# Altium schematic sheet CHC5_Regs.SchDoc
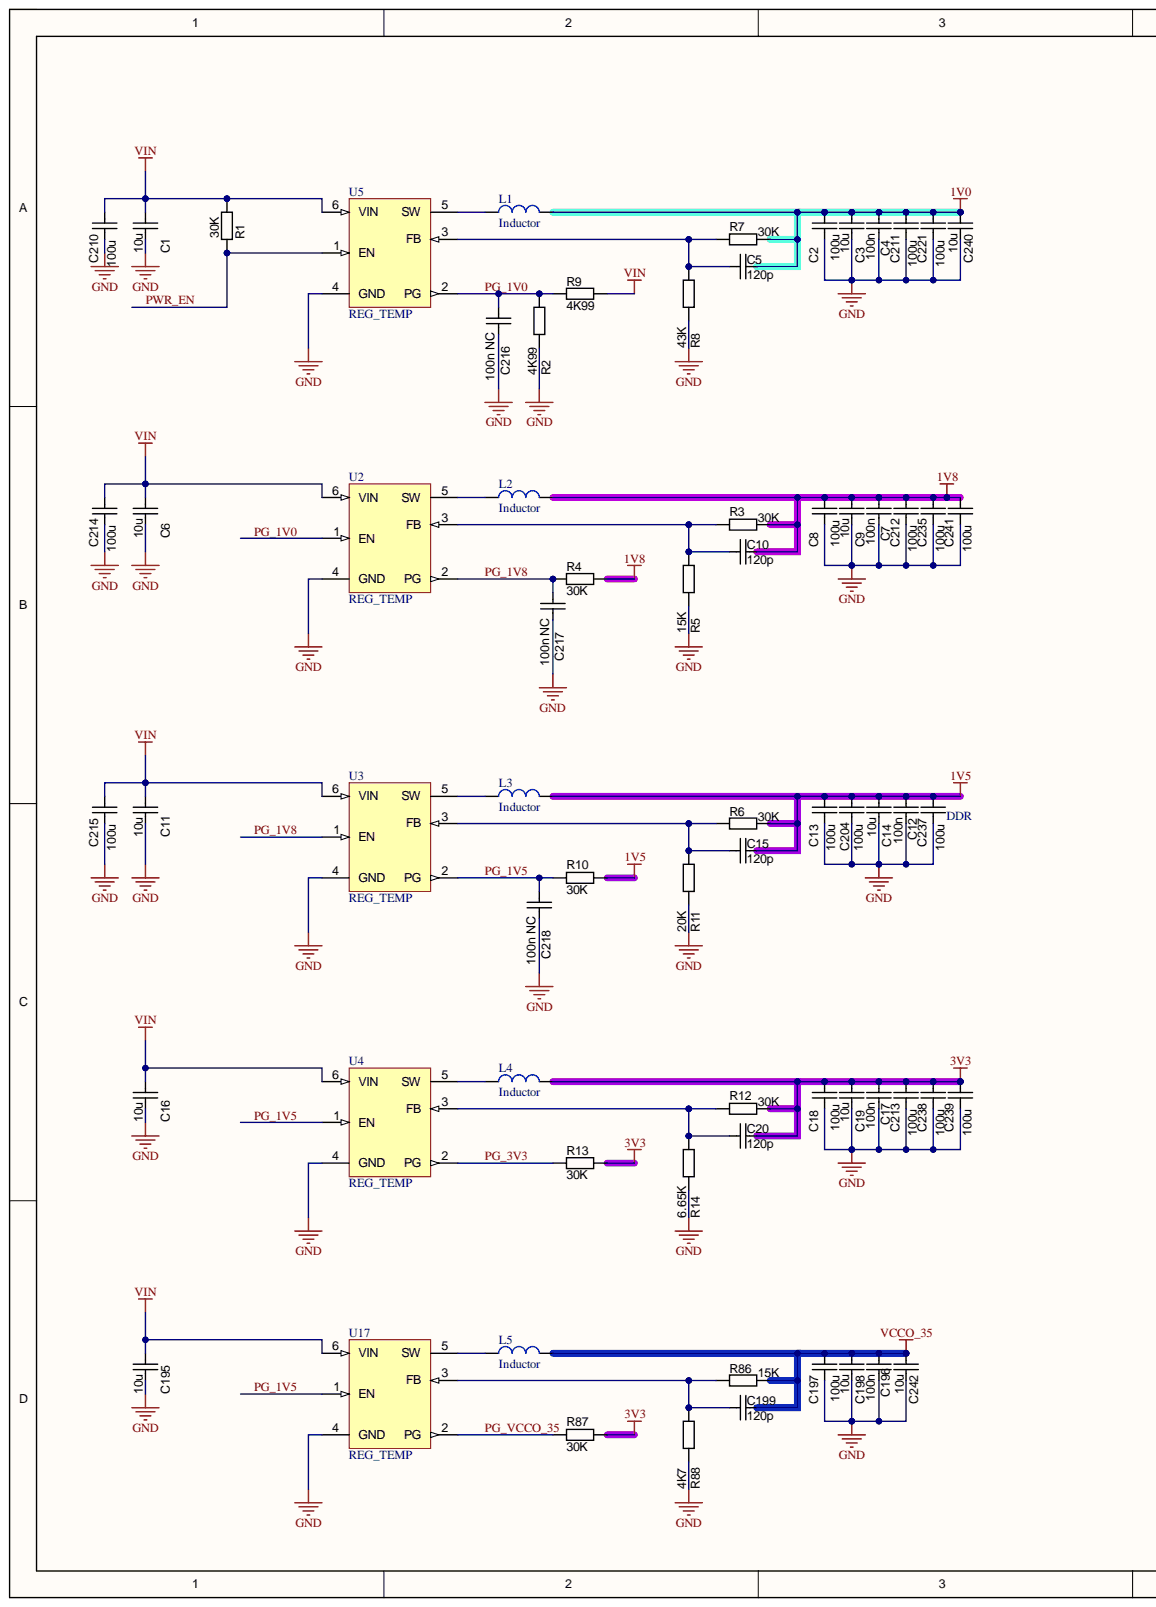
[diagram p0: CHC5_Regs.SchDoc, left]
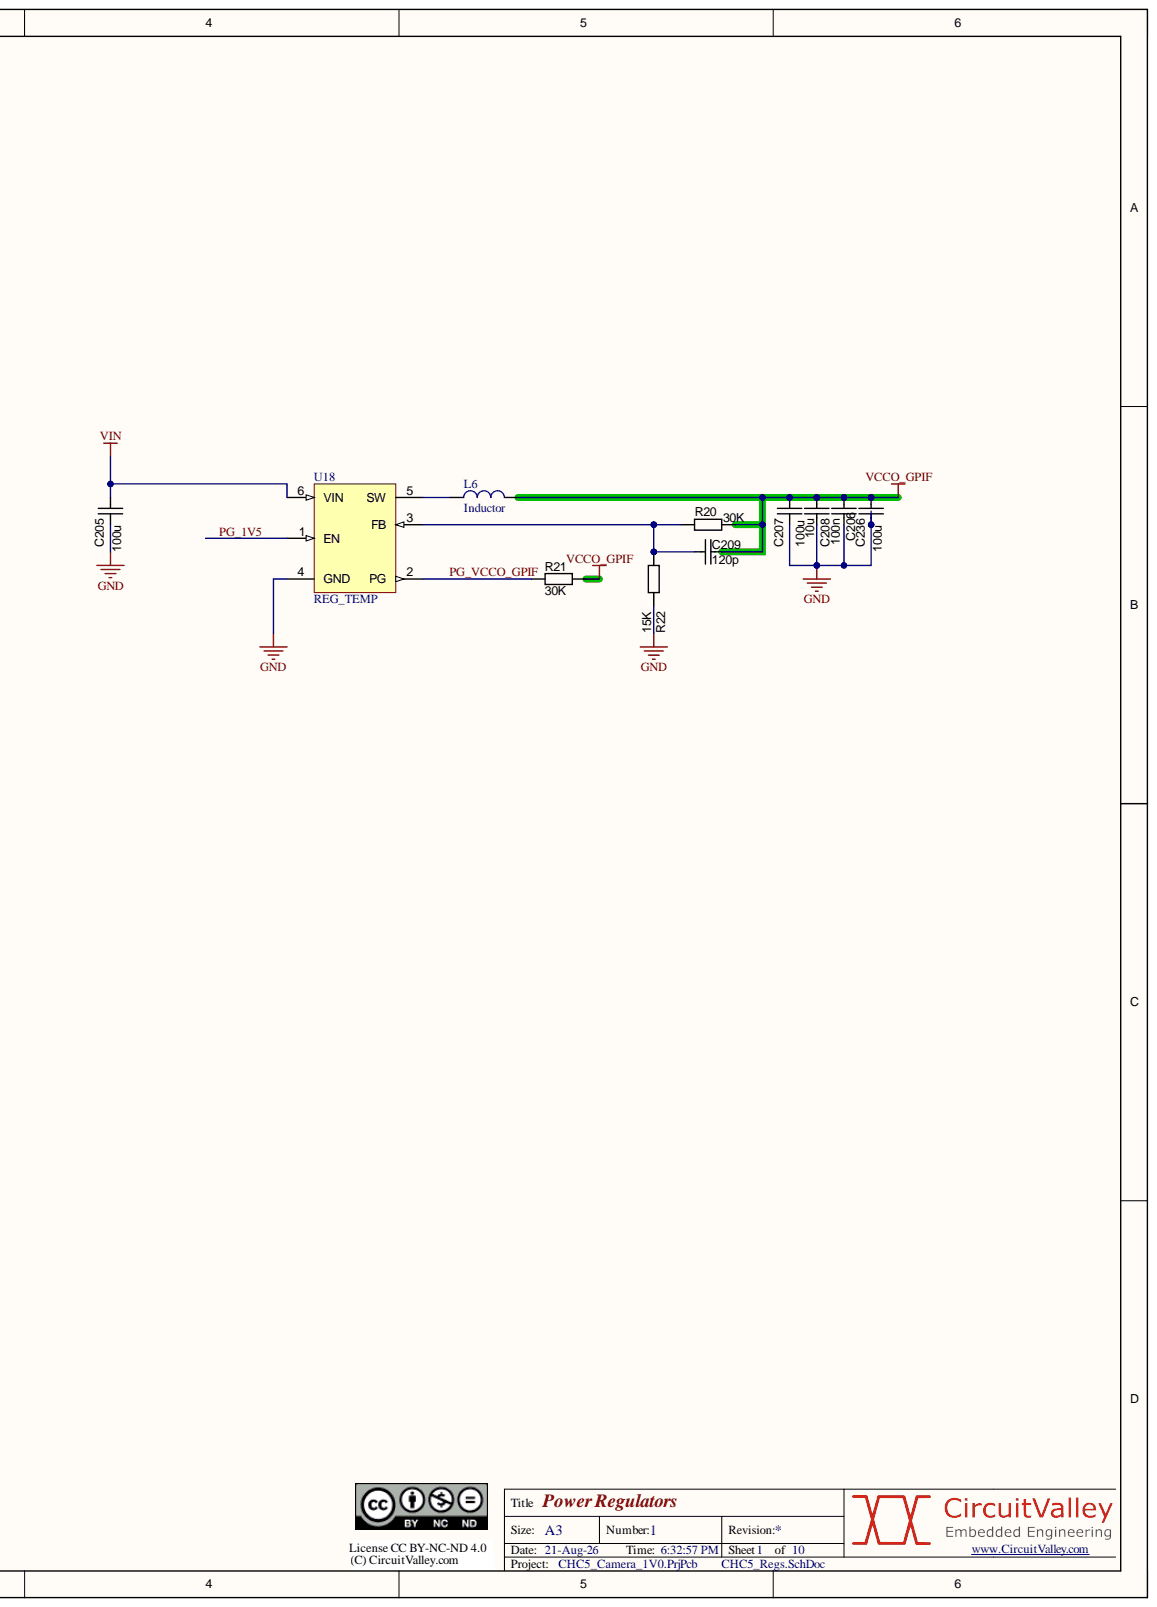
[diagram p1: CHC5_Regs.SchDoc, right]

## Parts
C1  value 10u  [CAPC1608N]  (hidden comment: approved sub OK)
C2  value 100u  [CAPC2012N]  (hidden comment: approved sub OK)
C3  value 10u  [CAPC1608N]  (hidden comment: approved sub OK)
C4  100n  [CAPC1005N]
C5  120p  [CAPC1005N]
C6  value 10u  [CAPC1608N]  (hidden comment: approved sub OK)
C7  100n  [CAPC1005N]
C8  value 100u  [CAPC2012N]  (hidden comment: approved sub OK)
C9  value 10u  [CAPC1608N]  (hidden comment: approved sub OK)
C10  120p  [CAPC1005N]
C11  value 10u  [CAPC1608N]  (hidden comment: approved sub OK)
C12  100n  [CAPC1005N]
C13  value 100u  [CAPC2012N]  (hidden comment: approved sub OK)
C14  value 10u  [CAPC1608N]  (hidden comment: approved sub OK)
C15  120p  [CAPC1005N]
C16  value 10u  [CAPC1608N]  (hidden comment: approved sub OK)
C17  100n  [CAPC1005N]
C18  value 100u  [CAPC2012N]  (hidden comment: approved sub OK)
C19  value 10u  [CAPC1608N]  (hidden comment: approved sub OK)
C20  120p  [CAPC1005N]
C195  value 10u  [CAPC1608N]  (hidden comment: approved sub OK)
C196  100n  [CAPC1005N]
C197  value 100u  [CAPC2012N]  (hidden comment: approved sub OK)
C198  value 10u  [CAPC1608N]  (hidden comment: approved sub OK)
C199  120p  [CAPC1005N]
C204  value 100u  [CAPC2012N]  (hidden comment: approved sub OK)
C205  value 100u  [CAPC2012N]  (hidden comment: approved sub OK)
C206  100n  [CAPC1005N]
C207  value 100u  [CAPC2012N]  (hidden comment: approved sub OK)
C208  value 10u  [CAPC1608N]  (hidden comment: approved sub OK)
C209  120p  [CAPC1005N]
C210  value 100u  [CAPC2012N]  (hidden comment: approved sub OK)
C211  value 100u  [CAPC2012N]  (hidden comment: approved sub OK)
C212  value 100u  [CAPC2012N]  (hidden comment: approved sub OK)
C213  value 100u  [CAPC2012N]  (hidden comment: approved sub OK)
C214  value 100u  [CAPC2012N]  (hidden comment: approved sub OK)
C215  value 100u  [CAPC2012N]  (hidden comment: approved sub OK)
C216  100n NC  [CAPC1005N]
C217  100n NC  [CAPC1005N]
C218  100n NC  [CAPC1005N]
C221  value 100u  [CAPC2012N]  (hidden comment: approved sub OK)
C235  value 100u  [CAPC2012N]  (hidden comment: approved sub OK)
C236  value 100u  [CAPC2012N]  (hidden comment: approved sub OK)
C237  value 100u  [CAPC2012N]  (hidden comment: approved sub OK)
C238  value 100u  [CAPC2012N]  (hidden comment: approved sub OK)
C239  value 100u  [CAPC2012N]  (hidden comment: approved sub OK)
C240  value 10u  [CAPC1608N]  (hidden comment: approved sub OK)
C241  value 100u  [CAPC2012N]  (hidden comment: approved sub OK)
C242  value 10u  [CAPC1608N]  (hidden comment: approved sub OK)
L1  Inductor  [TFM201610ALC-R47MTAA]
L2  Inductor  [TFM201610ALC-R47MTAA]
L3  Inductor  [TFM201610ALC-R47MTAA]
L4  Inductor  [TFM201610ALC-R47MTAA]
L5  Inductor  [TFM201610ALC-R47MTAA]
L6  Inductor  [TFM201610ALC-R47MTAA]
R1  value 30K  [RESC1005N]  (hidden comment: Thick Film)
R2  value 4K99  [RESC1005N]  (hidden comment: Thick Film)
R3  value 30K  [RESC1005N]  (hidden comment: Thick Film)
R4  value 30K  [RESC1005N]  (hidden comment: Thick Film)
R5  value 15K  [RESC1005N]  (hidden comment: Thick Film)
R6  value 30K  [RESC1005N]  (hidden comment: Thick Film)
R7  value 30K  [RESC1005N]  (hidden comment: Thick Film)
R8  value 43K  [RESC1005N]  (hidden comment: Thick Film)
R9  value 4K99  [RESC1005N]  (hidden comment: Thick Film)
R10  value 30K  [RESC1005N]  (hidden comment: Thick Film)
R11  value 20K  [RESC1005N]  (hidden comment: Thick Film)
R12  value 30K  [RESC1005N]  (hidden comment: Thick Film)
R13  value 30K  [RESC1005N]  (hidden comment: Thick Film)
R14  value 6.65K  [RESC1005N]  (hidden comment: Thick Film)
R20  value 30K  [RESC1005N]  (hidden comment: Thick Film)
R21  value 30K  [RESC1005N]  (hidden comment: Thick Film)
R22  value 15K  [RESC1005N]  (hidden comment: Thick Film)
R86  value 15K  [RESC1005N]  (hidden comment: Thick Film)
R87  value 30K  [RESC1005N]  (hidden comment: Thick Film)
R88  value 4K7  [RESC1005N]  (hidden comment: Thick Film)
U2  REG_TEMP  [VSON-6]
U3  REG_TEMP  [VSON-6]
U4  REG_TEMP  [VSON-6]
U5  REG_TEMP  [VSON-6]
U17  REG_TEMP  [VSON-6]
U18  REG_TEMP  [VSON-6]

## Nets
1V0: C2.1 C3.1 C4.2 C5.2 C211.1 C221.1 C240.1 L1.2 R7.2
1V5: C12.2 C13.1 C14.1 C15.2 C204.1 C237.1 L3.2 R6.2 R10.1
1V8: C7.2 C8.1 C9.1 C10.2 C212.1 C235.1 C241.1 L2.2 R3.2 R4.1
3V3: C17.2 C18.1 C19.1 C20.2 C213.1 C238.1 C239.1 L4.2 R12.2 R13.1 R87.1
GND: C1.2 C2.2 C3.2 C4.1 C6.2 C7.1 C8.2 C9.2 C11.2 C12.1 C13.2 C14.2 C16.2 C17.1 C18.2 C19.2 C195.2 C196.1 C197.2 C198.2 C204.2 C205.2 C206.1 C207.2 C208.2 C210.2 C211.2 C212.2 C213.2 C214.2 C215.2 C216.1 C217.1 C218.1 C221.2 C235.2 C236.2 C237.2 C238.2 C239.2 C240.2 C241.2 C242.2 R2.2 R5.2 R8.2 R11.2 R14.2 R22.2 R88.2 U2.4(GND) U3.4(GND) U4.4(GND) U5.4(GND) U17.4(GND) U18.4(GND)
VCCO_35: C196.2 C197.1 C198.1 C199.2 C242.1 L5.2 R86.2
VCCO_GPIF: C206.2 C207.1 C208.1 C209.2 C236.1 L6.2 R20.2 R21.1
VIN: C1.1 C6.1 C11.1 C16.1 C195.1 C205.1 C210.1 C214.1 C215.1 R1.1 R9.1 U2.6(VIN) U3.6(VIN) U4.6(VIN) U5.6(VIN) U17.6(VIN) U18.6(VIN)
PG_1V0: C216.2 R2.1 R9.2 U2.1(EN) U5.2(PG)
PG_1V5: C218.2 R10.2 U3.2(PG) U4.1(EN) U17.1(EN) U18.1(EN)
PG_1V8: C217.2 R4.2 U2.2(PG) U3.1(EN)
PG_3V3: R13.2 U4.2(PG)
PG_VCCO_35: R87.2 U17.2(PG)
PG_VCCO_GPIF: R21.2 U18.2(PG)
PWR_EN: R1.2 U5.1(EN)
NetC5_1: C5.1 R7.1 R8.1 U5.3(FB)
NetC10_1: C10.1 R3.1 R5.1 U2.3(FB)
NetC15_1: C15.1 R6.1 R11.1 U3.3(FB)
NetC20_1: C20.1 R12.1 R14.1 U4.3(FB)
NetC199_1: C199.1 R86.1 R88.1 U17.3(FB)
NetC209_1: C209.1 R20.1 R22.1 U18.3(FB)
NetL1_1: L1.1 U5.5(SW)
NetL2_1: L2.1 U2.5(SW)
NetL3_1: L3.1 U3.5(SW)
NetL4_1: L4.1 U4.5(SW)
NetL5_1: L5.1 U17.5(SW)
NetL6_1: L6.1 U18.5(SW)

## Library data of the parts
C1 C3 C6 C9 C11 C14 C16 C19 C195 C198 C208 C240 C242 = CAPC_560p_0603_5%: Capacitor SMD Cer 560pF 5% 50V NP0 0603; Manufacturer 1: AVX Corporation; Manufacturer Part Number 1: 06035A561JAT2A; Capacitance: 470pF; Material: ceramic; Ratings: 50V; NP0; Applications: General Purpose; Component Kind: Standard; ComponentLink1Description: http://www.avx.com/docs/Catalogs/ccog.pdf; ComponentLink1URL: http://www.avx.com/docs/Catalogs/ccog.pdf; Height - Seated (Max): -; Lead Spacing: -; Lead Style: -; Library Name: PBL - Capacitors.SCHLIB; Mounting Type: Surface Mount, MLCC; Operating Temperature: -55°C ~ 125°C; Package / Case: 0603 (1608 metric); Size / Dimension: 0.063" L x 0.032" W (1.60mm x 0.81mm); Supplier 1: Digi-Key; Supplier Part Number 1: 478-1184-1-ND; Thickness (Max): 0.035" (0.90mm); Voltage - Rated: 50V
C2 C8 C13 C18 C197 C204 C205 C207 C210-C215 C221 C235-C239 C241 = CAPC_1u_0805: Capacitor SMD Cer 1uF 10% 16V X7R 0805; Manufacturer 1: TDK Corporation; Manufacturer Part Number 1: C2012X7R1C105K/1.25; Material: ceramic; Ratings: 16V; X7R; Component Kind: Standard; Library Name: PBL - Capacitors.SCHLIB; Package / Case: 0805 (2012 metric); Supplier 1: Digi-Key; Supplier Part Number 1: 445-1358-1-ND; Tolerance: ±10%
C4 C5 C7 C10 C12 C15 C17 C20 C196 C199 C206 C209 C216-C218 = CAPC_10n_0402_16V_10%: Capacitor SMD Cer 10nF 10% 16V X7R 0402; Manufacturer 1: AVX Corporation; Manufacturer Part Number 1: 0402YC103KAT2A; Ratings: 16V; X7R; Component Kind: Standard; ComponentLink1Description: http://www.avx.com/docs/Catalogs/cx7r.pdf; ComponentLink1URL: http://www.avx.com/docs/Catalogs/cx7r.pdf; ComponentLink2Description: http://www.yageo.com/documents/recent/UPY-GPHC_X7R_6.3V-to-50V_8.pdf; ComponentLink2URL: http://www.yageo.com/documents/recent/UPY-GPHC_X7R_6.3V-to-50V_8.pdf; Library Name: PBL - Capacitors.SCHLIB; Manufacturer 2: Yageo; Manufacturer Part Number 2: CC0402KRX7R7BB103; Operating Temperature: -55°C ~ 125°C; Package / Case: 0402 (1005 Metric); Size / Dimension: 0.039" L x 0.020" W (1.00mm x 0.50mm); Supplier 1: Digi-Key; Supplier 2: Digi-Key; Supplier Part Number 1: 478-1114-1-ND; Supplier Part Number 2: 311-1042-1-ND; Tolerance: ±10%
  C4 C7 C12 C17 C196 C206: Value: 100n
  C5 C10 C15 C20 C199 C209: Value: 120p
  C216-C218: Value: 100n NC
L1-L6 = inductor_smd: Component Kind: Standard; Library Name: Inductor.SchLib
R1-R14 R20-R22 R86-R88 = RES_3K3_0402_5%: Resistor SMD chip 3.3k Ohm 0.063W 5% 0402; Manufacturer 1: Yageo; Manufacturer Part Number 1: RC0402JR-073K3L; Ratings: 1/16 W; Component Kind: Standard; ComponentLink1Description: http://www.yageo.com/documents/recent/PYu-RC0402_51_RoHS_L_6.pdf; ComponentLink1URL: http://www.yageo.com/documents/recent/PYu-RC0402_51_RoHS_L_6.pdf; Library Name: PBL - Resistors.SCHLIB; Material: Thick Film; Package / Case: 0402 (1005 metric); Supplier 1: Digi-Key; Supplier Part Number 1: 311-3.3KJRCT-ND; Tolerance: ±5%
U2-U5 U17 U18 = TPS6282x: Component Kind: Standard; Library Name: cvlib.SchLib
